# Altium schematic sheet pca20018 - Radio.SchDoc
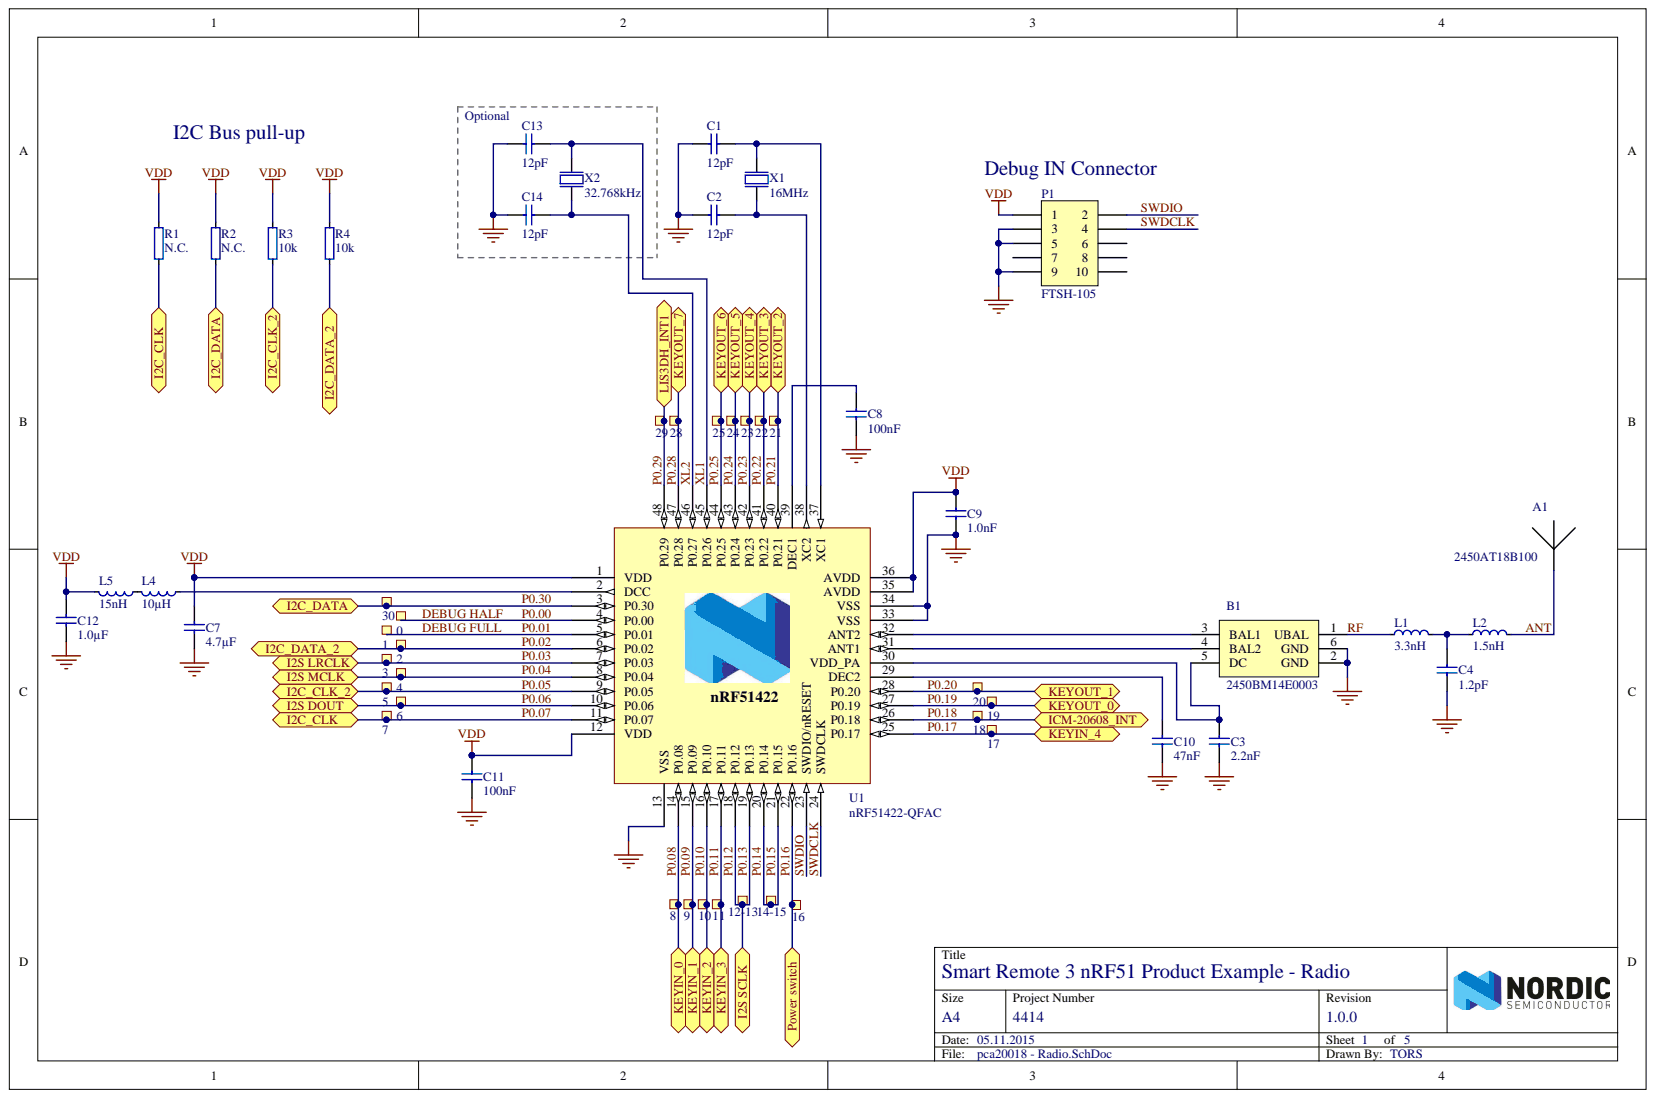
[diagram p0: pca20018 - Radio.SchDoc]

## Parts
0  Ø1.0mm,SMD  [TP_SMD_Ø1.0mm]  (value not drawn)  (no BOM)
1  Ø1.0mm,SMD  [TP_SMD_Ø1.0mm]  (value not drawn)  (no BOM)
2  Ø1.0mm,SMD  [TP_SMD_Ø1.0mm]  (value not drawn)  (no BOM)
3  Ø1.0mm,SMD  [TP_SMD_Ø1.0mm]  (value not drawn)  (no BOM)
4  Ø1.0mm,SMD  [TP_SMD_Ø1.0mm]  (value not drawn)  (no BOM)
5  Ø1.0mm,SMD  [TP_SMD_Ø1.0mm]  (value not drawn)  (no BOM)
6  Ø1.0mm,SMD  [TP_SMD_Ø1.0mm]  (value not drawn)  (no BOM)
7  Ø1.0mm,SMD  [TP_SMD_Ø1.0mm]  (value not drawn)  (no BOM)
8  Ø1.0mm,SMD  [TP_SMD_Ø1.0mm]  (value not drawn)  (no BOM)
9  Ø1.0mm,SMD  [TP_SMD_Ø1.0mm]  (value not drawn)  (no BOM)
10  Ø1.0mm,SMD  [TP_SMD_Ø1.0mm]  (value not drawn)  (no BOM)
11  Ø1.0mm,SMD  [TP_SMD_Ø1.0mm]  (value not drawn)  (no BOM)
12-13  Ø1.0mm,SMD  [TP_SMD_Ø1.0mm]  (value not drawn)  (no BOM)
14-15  Ø1.0mm,SMD  [TP_SMD_Ø1.0mm]  (value not drawn)  (no BOM)
16  Ø1.0mm,SMD  [TP_SMD_Ø1.0mm]  (value not drawn)  (no BOM)
17  Ø1.0mm,SMD  [TP_SMD_Ø1.0mm]  (value not drawn)  (no BOM)
18  Ø1.0mm,SMD  [TP_SMD_Ø1.0mm]  (value not drawn)  (no BOM)
19  Ø1.0mm,SMD  [TP_SMD_Ø1.0mm]  (value not drawn)  (no BOM)
20  Ø1.0mm,SMD  [TP_SMD_Ø1.0mm]  (value not drawn)  (no BOM)
21  Ø1.0mm,SMD  [TP_SMD_Ø1.0mm]  (value not drawn)  (no BOM)
22  Ø1.0mm,SMD  [TP_SMD_Ø1.0mm]  (value not drawn)  (no BOM)
23  Ø1.0mm,SMD  [TP_SMD_Ø1.0mm]  (value not drawn)  (no BOM)
24  Ø1.0mm,SMD  [TP_SMD_Ø1.0mm]  (value not drawn)  (no BOM)
25  Ø1.0mm,SMD  [TP_SMD_Ø1.0mm]  (value not drawn)  (no BOM)
28  Ø1.0mm,SMD  [TP_SMD_Ø1.0mm]  (value not drawn)  (no BOM)
29  Ø1.0mm,SMD  [TP_SMD_Ø1.0mm]  (value not drawn)  (no BOM)
30  Ø1.0mm,SMD  [TP_SMD_Ø1.0mm]  (value not drawn)  (no BOM)
A1  2450AT18B100  [2450AT18B100]
B1  2450BM14E0003  [BAL-0603-6pin]
C1  12pF  [CAPC1005X04L]
C2  12pF  [CAPC1005X04L]
C3  2.2nF  [CAPC1005X04L]
C4  1.2pF  [CAPC1005X04L]
C7  4.7µF  [CAPC1608X06L]
C8  100nF  [CAPC1005X04L]
C9  1.0nF  [CAPC1005X04L]
C10  47nF  [CAPC1005X04L]
C11  100nF  [CAPC1005X04L]
C12  1.0µF  [CAPC1608X06L]
C13  12pF  [CAPC1005X04L]
C14  12pF  [CAPC1005X04L]
L1  3.3nH  [INDC1005X04L]
L2  1.5nH  [INDC1005X04L]
L4  10µH  [INDC1608X06L]
L5  15nH  [INDC1005X04L]
P1  FTSH-105  [FTSH-105-K]
R1  N.C.  [RESC1005X04L]
R2  N.C.  [RESC1005X04L]
R3  10k  [RESC1005X04L]
R4  10k  [RESC1005X04L]
U1  nRF51422-QFAC  [QFN40P600X600X90-48N]
X1  16MHz  [BT-XTAL_2520]
X2  32.768kHz  [XTAL_3215]

## Ports
I2C_CLK, I2C_CLK_2, I2C_DATA, I2C_DATA_2, I2S DOUT, I2S LRCLK, I2S MCLK, I2S SCLK, ICM-20608_INT, KEYIN_0, KEYIN_1, KEYIN_2, KEYIN_3, KEYIN_4, KEYOUT_0, KEYOUT_1, KEYOUT_2, KEYOUT_3, KEYOUT_4, KEYOUT_5, KEYOUT_6, KEYOUT_7, LIS3DH_INT1, POWER SWITCH

## Nets
GND: B1.2(GND) B1.6(GND) C1.1 C2.1 C3.2 C4.1 C7.2 C8.2 C9.1 C10.2 C11.1 C12.1 C13.1 C14.1 P1.3 P1.5 P1.9 U1.13(VSS) U1.33(VSS) U1.34(VSS) U1.49 X1.2 X1.4
VDD: C7.1 C9.2 C11.2 C12.2 L5.2 P1.1 R1.2 R2.2 R3.2 R4.2 U1.1(VDD) U1.12(VDD) U1.35(AVDD) U1.36(AVDD)
ANT: A1.1 L2.2
DEBUG FULL: 1.1 U1.5(P0.01)
DEBUG HALF: 0.1 U1.4(P0.00)
P0.02: 2.1 R4.1 U1.6(P0.02) ; port I2C_DATA_2
P0.03: 3.1 U1.7(P0.03) ; port I2S LRCLK
P0.04: 4.1 U1.8(P0.04) ; port I2S MCLK
P0.05: 5.1 R3.1 U1.9(P0.05) ; port I2C_CLK_2
P0.06: 6.1 U1.10(P0.06) ; port I2S DOUT
P0.07: 7.1 R1.1 U1.11(P0.07) ; port I2C_CLK
P0.08: 8.1 U1.14(P0.08) ; port KEYIN_0
P0.09: 9.1 U1.15(P0.09) ; port KEYIN_1
P0.10: 10.1 U1.16(P0.10) ; port KEYIN_2
P0.11: 11.1 U1.17(P0.11) ; port KEYIN_3
P0.12: 12-13.1 U1.18(P0.12) U1.19(P0.13) ; port I2S SCLK
P0.14: 14-15.1 U1.20(P0.14) U1.21(P0.15)
P0.16: 16.1 U1.22(P0.16) ; port POWER SWITCH
P0.17: 17.1 U1.25(P0.17) ; port KEYIN_4
P0.18: 18.1 U1.26(P0.18) ; port ICM-20608_INT
P0.19: 19.1 U1.27(P0.19) ; port KEYOUT_0
P0.20: 20.1 U1.28(P0.20) ; port KEYOUT_1
P0.21: 21.1 U1.40(P0.21) ; port KEYOUT_2
P0.22: 22.1 U1.41(P0.22) ; port KEYOUT_3
P0.23: 23.1 U1.42(P0.23) ; port KEYOUT_4
P0.24: 24.1 U1.43(P0.24) ; port KEYOUT_5
P0.25: 25.1 U1.44(P0.25) ; port KEYOUT_6
P0.28: 28.1 U1.47(P0.28) ; port KEYOUT_7
P0.29: 29.1 U1.48(P0.29) ; port LIS3DH_INT1
P0.30: 30.1 R2.1 U1.3(P0.30) ; port I2C_DATA
RF: B1.1(UBAL) L1.2
SWDCLK: P1.4 U1.24(SWDCLK)
SWDIO: P1.2 U1.23(SWDIO/nRESET)
XL1: C13.2 U1.45(P0.26) X2.1
XL2: C14.2 U1.46(P0.27) X2.2
NetB1_3: B1.3(BAL1) U1.32(ANT2)
NetB1_4: B1.4(BAL2) U1.31(ANT1)
NetB1_5: B1.5(DC) C3.1 U1.30(VDD_PA)
NetC1_2: C1.2 U1.37(XC1) X1.1
NetC2_2: C2.2 U1.38(XC2) X1.3
NetC4_2: C4.2 L1.1 L2.1
NetC8_1: C8.1 U1.39(DEC1)
NetC10_1: C10.1 U1.29(DEC2)
NetL4_1: L4.1 U1.2(DCC)
NetL4_2: L4.2 L5.1

Not connected: P1 6 7 8 10

## Library data of the parts
0-11 12-13 14-15 16-25 28-30 = TP_SMD_Ø1.0MM: 1.0mm circular SMD testpad; Manufacturer: Nordic Semiconductor; Artikkelnr Noca: N.A.; Database Table Name: Connectors$; Artikkelnr Simpro: N.A.; Footprint Doc: TP_SMD_Ø1.0mm; LatestRevisionDate: 2013-06-11; Library Name: Nordic Passive DB.DbLib; Part Number: TP_SMD_Ø1.0MM; Pin Count: 1; Published: 2013-06-11; Value: Ø1.0mm,SMD; Publisher: Nordic Semiconductor
A1 = Johanson 2450AT18B100: 2.45 GHz chip antenna; Manufacturer: Johanson Technology; Artikkelnr Noca: N.A.; Database Table Name: Antennas$; Artikkelnr Simpro: N.A.; Component Kind: Standard; Datasheet: http://www.johansontechnology.com/images/stories/ip/rf-antennas/antenna_2450at18b100.pdf; Footprint Doc: 2450AT18B100; LatestRevisionDate: 2013-09-13; Library Name: Nordic Passive DB.DbLib; Manufacturer Part Number: 2450AT18B100; Part Number: Johanson 2450AT18B100; Pin Count: 2; Published: 2013-09-13; Supplier: Digi-Key; Supplier part number: 712-1006-1-ND; Value: 2450AT18B100; Publisher: Nordic Semiconductor
B1 = 2450BM14E0003: 2.45 GHz Impedance Matched Balun-Filter; Manufacturer: Johanson Technology; Artikkelnr Noca: N.A.; Database Table Name: Baluns$; Note: Optimized for nRF51x22-QFAA; Artikkelnr Simpro: N.A.; Component Kind: Standard; Footprint Doc: BAL-0603-6pin; LatestRevisionDate: 2014-03-31; Library Name: Nordic Passive DB.DbLib; Manufacturer Part Number: 2450BM14E0003; Part Number: 2450BM14E0003; Pin Count: 6; Published: 2013-10-06; Supplier: Digi-Key; Supplier part number: 712-1581-1-ND; Value: 2450BM14E0003; Publisher: Nordic Semiconductor
C1 C2 C13 C14 = C0402-12p: Capacitor, NP0, ±2%; Artikkelnr Noca: 20029159; Artikkelnr Simpro: N.A.; Database Table Name: Capacitors$; Note: 50V; Component Kind: Standard; Footprint Doc: 0402; LatestRevisionDate: 2011-05-11; Library Name: Nordic Passive DB.DbLib; Part Number: C0402-12p; Pin Count: 2; Published: 2009-05-08; Value: 12pF; Publisher: Nordic Semiconductor
C3 = C0402-2n2: Capacitor, X7R, ±10%; Artikkelnr Noca: 20039221; Artikkelnr Simpro: N.A.; Database Table Name: Capacitors$; Note: 50V; Component Kind: Standard; Footprint Doc: 0402; LatestRevisionDate: 2009-11-23; Library Name: Nordic Passive DB.DbLib; Part Number: C0402-2n2; Pin Count: 2; Published: 2009-05-08; Value: 2.2nF; Publisher: Nordic Semiconductor
C4 = C0402-1.2p: Capacitor, NP0, ±0.1pF; Artikkelnr Noca: 20029157; Artikkelnr Simpro: N.A.; Database Table Name: Capacitors$; Note: 50V; Component Kind: Standard; Footprint Doc: 0402; LatestRevisionDate: 2011-05-11; Library Name: Nordic Passive DB.DbLib; Part Number: C0402-1.2p; Pin Count: 2; Published: 2009-05-08; Value: 1.2pF; Publisher: Nordic Semiconductor
C7 = C0603-4µ7: Capacitor, X5R, ±10%; Artikkelnr Noca: 20069060; Artikkelnr Simpro: N.A.; Database Table Name: Capacitors$; Note: 6.3V; Component Kind: Standard; Footprint Doc: 0603; LatestRevisionDate: 2009-11-23; Library Name: Nordic Passive DB.DbLib; Part Number: C0603-4µ7; Pin Count: 2; Published: 2009-05-08; Value: 4.7µF; Publisher: Nordic Semiconductor
C8 C11 = C0402-100n: Capacitor, X7R, ±10%; Artikkelnr Noca: 20039200; Artikkelnr Simpro: N.A.; Database Table Name: Capacitors$; Note: 16V; Component Kind: Standard; Footprint Doc: 0402; LatestRevisionDate: 2009-11-23; Library Name: Nordic Passive DB.DbLib; Part Number: C0402-100n; Pin Count: 2; Published: 2009-05-08; Value: 100nF; Publisher: Nordic Semiconductor
C9 = C0402-1n0: Capacitor, X7R, ±10%; Artikkelnr Noca: 20039223; Artikkelnr Simpro: N.A.; Database Table Name: Capacitors$; Note: 50V; Component Kind: Standard; Footprint Doc: 0402; LatestRevisionDate: 2009-11-23; Library Name: Nordic Passive DB.DbLib; Part Number: C0402-1n0; Pin Count: 2; Published: 2009-05-08; Value: 1.0nF; Publisher: Nordic Semiconductor
C10 = C0402-47n: Capacitor, X7R, ±10%; Artikkelnr Noca: 20039389; Artikkelnr Simpro: N.A.; Database Table Name: Capacitors$; Note: Chip Cap 10%; Component Kind: Standard; Footprint Doc: 0402; LatestRevisionDate: 2009-05-08; Library Name: Nordic Passive DB.DbLib; Part Number: C0402-47n; Pin Count: 2; Published: 2009-05-08; Value: 47nF; Publisher: Nordic Semiconductor
C12 = C0603-1µ0: Capacitor, X7R, ±10%; Artikkelnr Noca: 20039335; Artikkelnr Simpro: N.A.; Database Table Name: Capacitors$; Note: 16V; Component Kind: Standard; Footprint Doc: 0603; LatestRevisionDate: 2013-06-05; Library Name: Nordic Passive DB.DbLib; Part Number: C0603-1µ0; Pin Count: 2; Published: 2009-05-08; Value: 1.0µF; Publisher: Nordic Semiconductor
L1 = L0402-3n3: Inductor, 190mA, ±0.1nH; Manufacturer: Murata; Manufacturer Part Number: LQP15MN3N3B02D; Artikkelnr Noca: 33029369; Artikkelnr Simpro: N.A.; Database Table Name: Inductors$; Note: High frequency chip inductor ±5%; Component Kind: Standard; Datasheet: http://search.murata.co.jp/Ceramy/image/img/PDF/ENG/L0075S0084LQP15M.pdf; Footprint Doc: 0402; LatestRevisionDate: 2012-09-19; Library Name: Nordic Passive DB.DbLib; Part Number: L0402-3n3; Pin Count: 2; Published: 2009-05-08; Supplier: Digi-Key; Supplier part number: 490-1130-1-ND; Value: 3.3nH; Publisher: Nordic Semiconductor
L2 = L0402-1n5: Inductor, 280mA, ±0.1nH; Manufacturer: Murata; Manufacturer Part Number: LQP15MN1N5B02D; Artikkelnr Noca: N.A.; Database Table Name: Inductors$; Note: High frequency chip inductor ±5%; Artikkelnr Simpro: N.A.; Component Kind: Standard; Datasheet: http://search.murata.co.jp/Ceramy/image/img/PDF/ENG/L0075S0084LQP15M.pdf; Footprint Doc: 0402; LatestRevisionDate: 2012-09-19; Library Name: Nordic Passive DB.DbLib; Part Number: L0402-1n5; Pin Count: 2; Published: 2009-05-08; Supplier: Digi-Key; Supplier part number: 490-1126-1-ND; Value: 1.5nH; Publisher: Nordic Semiconductor
L4 = L0603-10µ: Inductor, 80mA, ±10%; 2nd source part number: 178-6105; Manufacturer: Taiyo Yuden; Manufacturer Part Number: LBMF1608T100K; Artikkelnr Noca: 33029314; Artikkelnr Simpro: N.A.; Database Table Name: Inductors$; Note: LBMF1608T100K 10µH 80mA 0603; 2nd source Supplier: Farnell; Component Kind: Standard; Datasheet: http://www.yuden.co.jp/ut/product/pdf/elb2_e.pdf; Footprint Doc: 0603; LatestRevisionDate: 2012-09-19; Library Name: Nordic Passive DB.DbLib; Part Number: L0603-10µ; Pin Count: 2; Published: 2010-02-24; Supplier: Digi-Key; Supplier part number: 587-1714-1-ND; Value: 10µH; Publisher: Nordic Semiconductor
L5 = L0402-15n: Inductor, 320mA, ±5%; 2nd source part number: 658-1328; Manufacturer: Taiyo Yuden; Manufacturer Part Number: HK100515NJ-T; Artikkelnr Noca: 33029323; Artikkelnr Simpro: N.A.; Database Table Name: Inductors$; Note: High frequency chip inductor ±10%; 2nd source Supplier: Farnell; Component Kind: Standard; Datasheet: http://www.yuden.co.jp/productdata/catalog/en/mlci04_e.pdf; Footprint Doc: 0402; LatestRevisionDate: 2012-09-19; Library Name: Nordic Passive DB.DbLib; Part Number: L0402-15n; Pin Count: 2; Published: 2010-07-12; Supplier: Digi-Key; Supplier part number: 587-1521-1-ND; Value: 15nH; Publisher: Nordic Semiconductor
P1 = Samtec FTSH-105 Keying Shroud: SAMTEC - CONN HEADER 10POS DUAL .05" SMD KEYING SHROUD; Manufacturer Part Number: FTSH-105-01-F-DV-K; Artikkelnr Noca: N.A.; Database Table Name: Connectors$; Note: HEADER, 1.27MM, SMD, 10WAY, Keying Shroud; Artikkelnr Simpro: N.A.; Component Kind: Standard; Datasheet: http://www.digikey.com/product-detail/en/FTSH-105-01-F-DV-K-P-TR/FTSH-105-01-F-DV-K-P-TR-ND/2649977; Footprint Doc: FTSH-105; LatestRevisionDate: 2014-05-23; Library Name: Nordic Passive DB.DbLib; Manufacturer: Samtec; Part Number: Samtec FTSH-105 Keying Shroud; Pin Count: 10; Published: 2014-05-23; Supplier: Digi-Key; Supplier part number: FTSH-105-01-F-DV-K-P-TR-ND; Value: FTSH-105; Publisher: Nordic Semiconductor
R1 R2 = R0402-N.C.: Not mounted; Artikkelnr Noca: N.A.; Database Table Name: Resistors$; Note: Chip Res; Artikkelnr Simpro: N.A.; Component Kind: Standard; Footprint Doc: 0402; LatestRevisionDate: 2009-05-08; Library Name: Nordic Passive DB.DbLib; Part Number: R0402-N.C.; Pin Count: 2; Published: 2009-05-08; Value: N.C.; Publisher: Nordic Semiconductor
R3 R4 = R0402-10k: Resistor, ±1%, 0.063W; Artikkelnr Noca: 27049097; Artikkelnr Simpro: N.A.; Database Table Name: Resistors$; Note: Chip Res 1%; Component Kind: Standard; Footprint Doc: 0402; LatestRevisionDate: 2009-11-23; Library Name: Nordic Passive DB.DbLib; Part Number: R0402-10k; Pin Count: 2; Published: 2009-05-08; Supplier: Farnell; Supplier part number: 173-8864; Value: 10k; Publisher: Nordic Semiconductor
U1 = nRF51422-QFAC-XLR3+: Multi-protocol Bluetooth Low Energy, ANT and 2.4GHz proprietary system-on-chip; Manufacturer: Nordic Semiconductor; Manufacturer Part Number: nRF51422-QFACAx; Artikkelnr Noca: 15079264; Artikkelnr Simpro: N.A.; Database Table Name: nRF Devices$; Note: nRF51422 - QFN48 6x6 0.4mm pitch; Component Kind: Standard; Footprint Doc: QFN-48; LatestRevisionDate: 2014-09-17; Library Name: Nordic Active DB.DbLib; Part Number: nRF51422-QFAC-XLR3+; Pin Count: 48; Published: 2014-06-04; Supplier: Nordic Semiconductor; Value: nRF51422-QFAC; Publisher: Nordic Semiconductor
X1 = XTAL_SMD2520_16MHz_8pF_NDK: XTAL SMD 2520, 16MHz, Cl=8pF, Tol: ±15ppm, Stab: ±25ppm; Manufacturer: NDK; Manufacturer Part Number: NX2520SA/16MHz/EXS00A-CS06713; Artikkelnr Noca: 22019192; Artikkelnr Simpro: N.A.; Database Table Name: Crystal_Osc$; Note: XTAL SMD 16.000MHz; Component Kind: Standard; Datasheet: http://www.ndk.com/images/products/catalog/c_NX2520SA-STD-CSW-5_e.pdf; Footprint Doc: XTAL_2520; LatestRevisionDate: 2014-11-27; Library Name: Nordic Discrete DB.DbLib; Part Number: XTAL_SMD2520_16MHz_8pF_NDK; Pin Count: 4; Published: 2012-06-15; Supplier: Digi-Key; Supplier part number: 644-1169-1-ND; Value: 16MHz; Publisher: Nordic Semiconductor
X2 = XTAL_FC-135 32.768k: XTAL SMD 3215, 32.768kHz, 9pF, ±20ppm; 2nd source part number: 127-8037; Manufacturer: Epson Toyocom; Manufacturer Part Number: FC-135 32.768KHZ ±20PPM,9.0PF; Artikkelnr Noca: 22019091; Artikkelnr Simpro: N.A.; Database Table Name: Crystal_Osc$; Note: XTAL SMD 32.768kHz, 3215; 2nd source Supplier: Farnell; Component Kind: Standard; Datasheet: http://www.farnell.com/datasheets/57480.pdf; Footprint Doc: XTAL_3215; LatestRevisionDate: 2014-03-27; Library Name: Nordic Discrete DB.DbLib; Part Number: XTAL_FC-135 32.768k; Pin Count: 2; Published: 2010-10-18; Supplier: Digi-Key; Supplier part number: FC-135 32.7680KA-AC-ND; Value: 32.768kHz; Publisher: Nordic Semiconductor
